# Altium schematic sheet Power.SchDoc(Power)
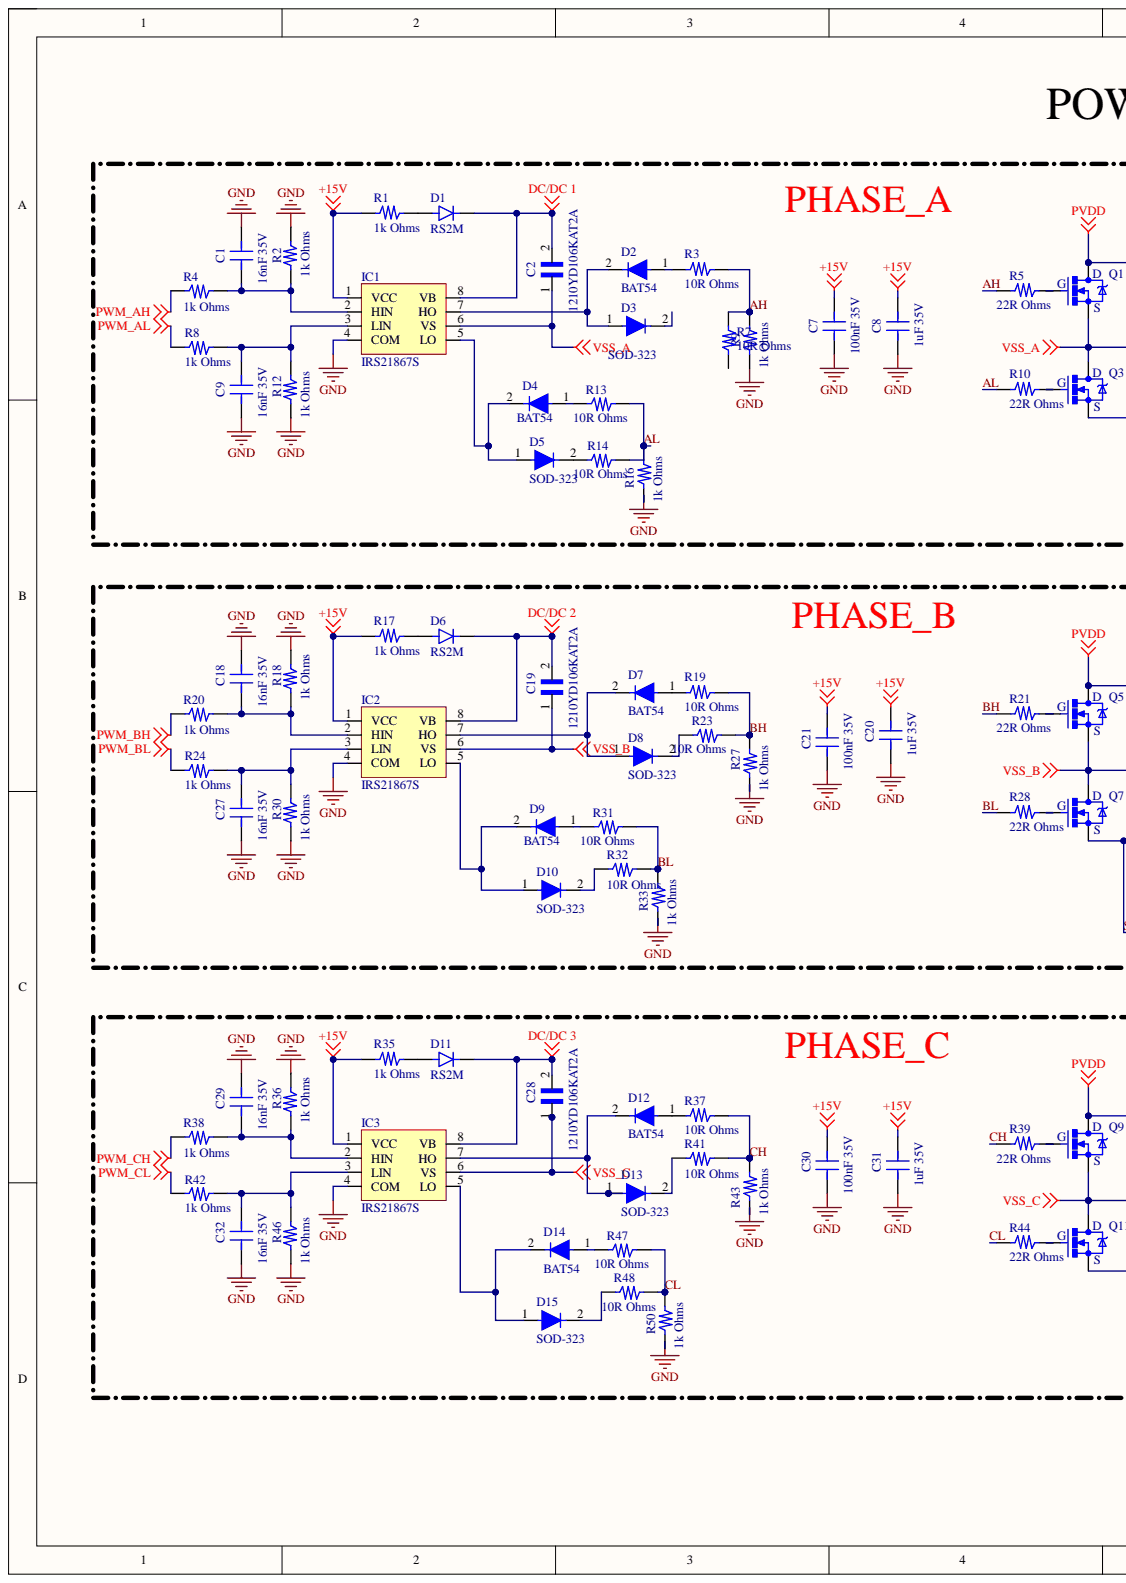
[diagram p0: Power.SchDoc, left]
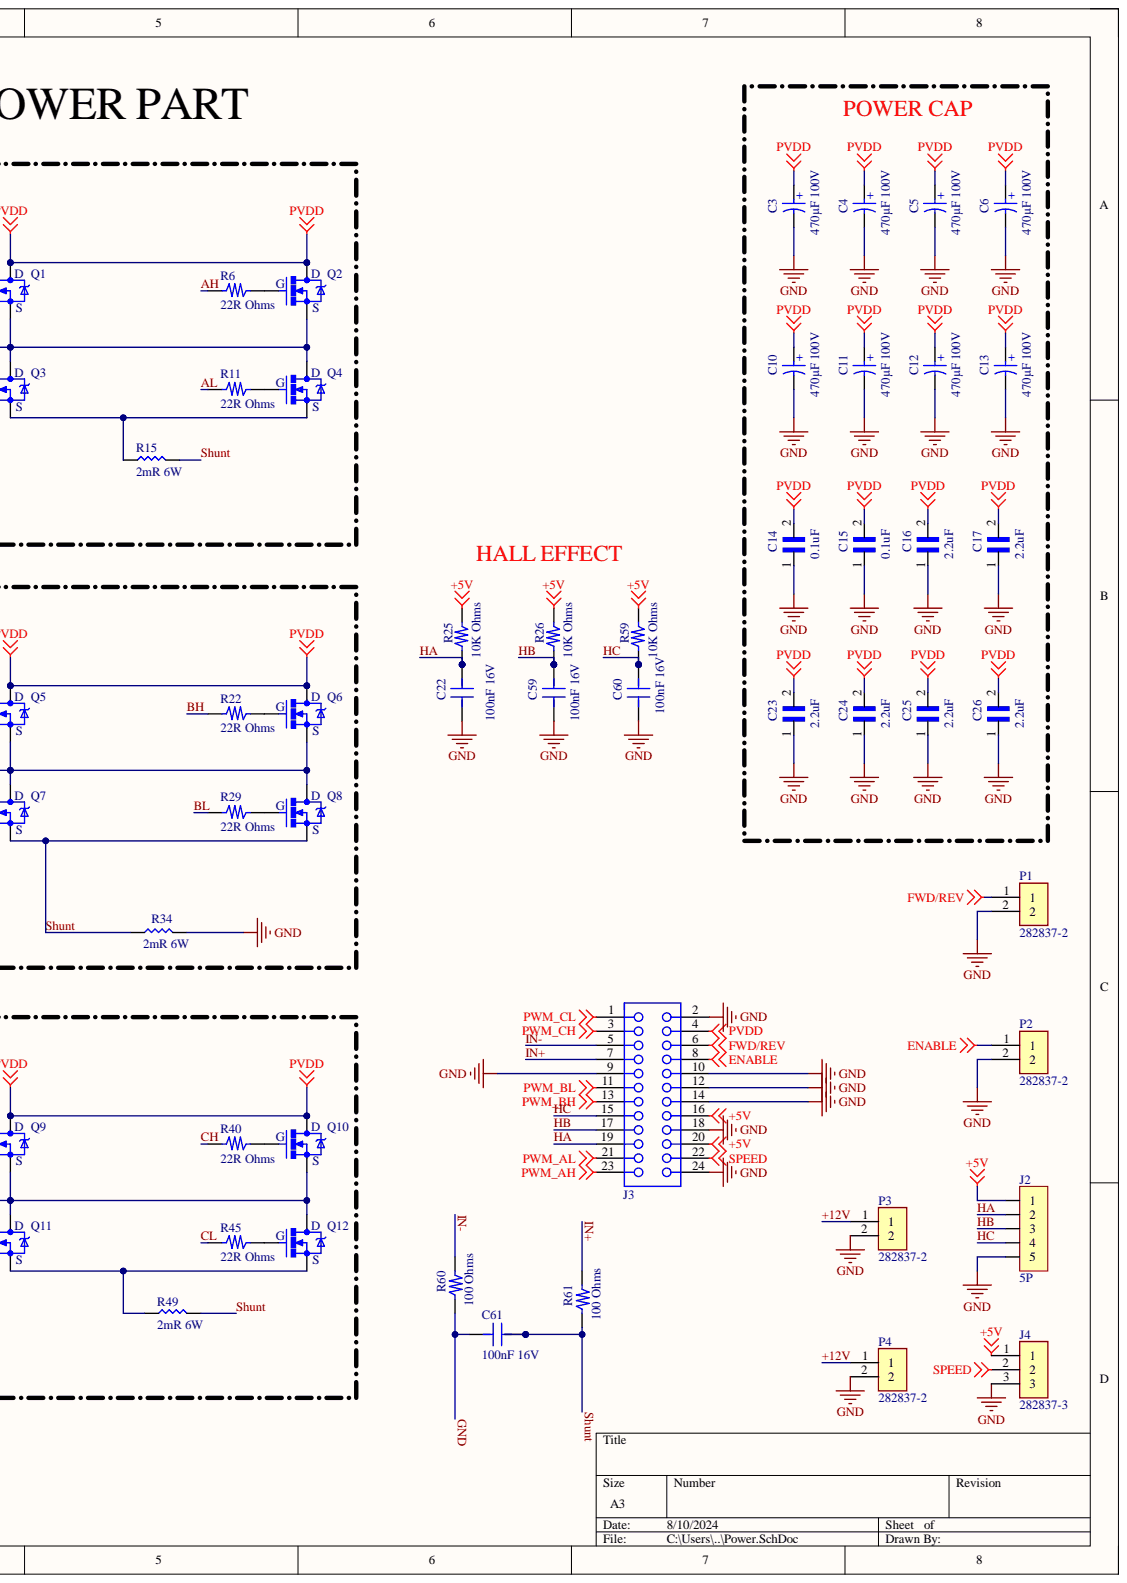
[diagram p1: Power.SchDoc, right]

## Parts
C1  16nF 35V  [0805_CAP]
C2  1210YD106KAT2A  [CAPC3225X279N]
C3  470µF 100V  Rated Voltage (DC): 100V  [WCAP-ATUL_D18H31.5]
C4  470µF 100V  Rated Voltage (DC): 100V  [WCAP-ATUL_D18H31.5]
C5  470µF 100V  Rated Voltage (DC): 100V  [WCAP-ATUL_D18H31.5]
C6  470µF 100V  Rated Voltage (DC): 100V  [WCAP-ATUL_D18H31.5]
C7  100nF 35V  [0805_CAP]
C8  value 1uF 35V  [0805_CAP]  (hidden comment: 10uF)
C9  16nF 35V  [0805_CAP]
C10  470µF 100V  Rated Voltage (DC): 100V  [WCAP-ATUL_D18H31.5]
C11  470µF 100V  Rated Voltage (DC): 100V  [WCAP-ATUL_D18H31.5]
C12  470µF 100V  Rated Voltage (DC): 100V  [WCAP-ATUL_D18H31.5]
C13  470µF 100V  Rated Voltage (DC): 100V  [WCAP-ATUL_D18H31.5]
C14  0.1uF  [CAPC3225X279N]
C15  0.1uF  [CAPC3225X279N]
C16  2.2uF  [CAPC3225X279N]
C17  2.2uF  [CAPC3225X279N]
C18  16nF 35V  [0805_CAP]
C19  1210YD106KAT2A  [CAPC3225X279N]
C20  value 1uF 35V  [0805_CAP]  (hidden comment: 10uF)
C21  100nF 35V  [0805_CAP]
C22  100nF 16V  [0805_CAP]
C23  2.2uF  [CAPC3225X279N]
C24  2.2uF  [CAPC3225X279N]
C25  2.2uF  [CAPC3225X279N]
C26  2.2uF  [CAPC3225X279N]
C27  16nF 35V  [0805_CAP]
C28  1210YD106KAT2A  [CAPC3225X279N]
C29  16nF 35V  [0805_CAP]
C30  100nF 35V  [0805_CAP]
C31  value 1uF 35V  [0805_CAP]  (hidden comment: 10uF)
C32  16nF 35V  [0805_CAP]
C59  100nF 16V  [0805_CAP]
C60  100nF 16V  [0805_CAP]
C61  100nF 16V  [0603_CAP]
D1  RS2M  [DIOM4336X265N]
D2  BAT54  [SOD323]
D3  SOD-323  [SOD323]
D4  BAT54  [SOD323]
D5  SOD-323  [SOD323]
D6  RS2M  [DIOM4336X265N]
D7  BAT54  [SOD323]
D8  SOD-323  [SOD323]
D9  BAT54  [SOD323]
D10  SOD-323  [SOD323]
D11  RS2M  [DIOM4336X265N]
D12  BAT54  [SOD323]
D13  SOD-323  [SOD323]
D14  BAT54  [SOD323]
D15  SOD-323  [SOD323]
IC1  IRS21867S  [IRS21867S]
IC2  IRS21867S  [IRS21867S]
IC3  IRS21867S  [IRS21867S]
J2  5P  [TBLOCK5P]
J3  61302421821  [61302421821]  (value not drawn)
J4  282837-3  [TE_282837-3]
P1  282837-2  [TE_282837-2]
P2  282837-2  [TE_282837-2]
P3  282837-2  [TE_282837-2]
P4  282837-2  [TE_282837-2]
Q1  IXTH200N10T  [TO-247]  (value not drawn)
Q2  IRFP4310ZPbF  [TO-247]  (value not drawn)
Q3  IRFP4310ZPbF  [TO-247]  (value not drawn)
Q4  IRFP4310ZPbF  [TO-247]  (value not drawn)
Q5  IXTH200N10T  [TO-247]  (value not drawn)
Q6  IRFP4310ZPbF  [TO-247]  (value not drawn)
Q7  IRFP4310ZPbF  [TO-247]  (value not drawn)
Q8  IRFP4310ZPbF  [TO-247]  (value not drawn)
Q9  IXTH200N10T  [TO-247]  (value not drawn)
Q10  IRFP4310ZPbF  [TO-247]  (value not drawn)
Q11  IRFP4310ZPbF  [TO-247]  (value not drawn)
Q12  IRFP4310ZPbF  [TO-247]  (value not drawn)
R1  1k Ohms  [0805_RES]
R2  1k Ohms  [0805_RES]
R3  10R Ohms  [0805_RES]
R4  1k Ohms  [0805_RES]
R5  22R Ohms  [0805_RES]
R6  22R Ohms  [0805_RES]
R7  10R Ohms  [0805_RES]
R8  1k Ohms  [0805_RES]
R9  1k Ohms  [0805_RES]
R10  22R Ohms  [0805_RES]
R11  22R Ohms  [0805_RES]
R12  1k Ohms  [0805_RES]
R13  10R Ohms  [0805_RES]
R14  10R Ohms  [0805_RES]
R15  2mR 6W  [3920]
R16  1k Ohms  [0805_RES]
R17  1k Ohms  [0805_RES]
R18  1k Ohms  [0805_RES]
R19  10R Ohms  [0805_RES]
R20  1k Ohms  [0805_RES]
R21  22R Ohms  [0805_RES]
R22  22R Ohms  [0805_RES]
R23  10R Ohms  [0805_RES]
R24  1k Ohms  [0805_RES]
R25  10K Ohms  [0805_RES]
R26  10K Ohms  [0805_RES]
R27  1k Ohms  [0805_RES]
R28  22R Ohms  [0805_RES]
R29  22R Ohms  [0805_RES]
R30  1k Ohms  [0805_RES]
R31  10R Ohms  [0805_RES]
R32  10R Ohms  [0805_RES]
R33  1k Ohms  [0805_RES]
R34  2mR 6W  [3920]
R35  1k Ohms  [0805_RES]
R36  1k Ohms  [0805_RES]
R37  10R Ohms  [0805_RES]
R38  1k Ohms  [0805_RES]
R39  22R Ohms  [0805_RES]
R40  22R Ohms  [0805_RES]
R41  10R Ohms  [0805_RES]
R42  1k Ohms  [0805_RES]
R43  1k Ohms  [0805_RES]
R44  22R Ohms  [0805_RES]
R45  22R Ohms  [0805_RES]
R46  1k Ohms  [0805_RES]
R47  10R Ohms  [0805_RES]
R48  10R Ohms  [0805_RES]
R49  2mR 6W  [3920]
R50  1k Ohms  [0805_RES]
R59  10K Ohms  [0805_RES]
R60  100 Ohms  [0603_RES]
R61  100 Ohms  [0603_RES]

## Nets
+5V: J2.1 J3.16 J3.20 J4.1 R25.2 R26.2 R59.2
+15V: C7.2 C8.2 C20.2 C21.2 C30.2 C31.2 IC1.1(VCC) IC2.1(VCC) IC3.1(VCC) R1.1 R17.1 R35.1
DC/DC 1: C2.2 D1.C IC1.8(VB)
DC/DC 2: C19.2 D6.C IC2.8(VB)
DC/DC 3: C28.2 D11.C IC3.8(VB)
ENABLE: J3.8 P2.1
FWD/REV: J3.6 P1.1
PVDD: C3.1 C4.1 C5.1 C6.1 C10.1 C11.1 C12.1 C13.1 C14.2 C15.2 C16.2 C17.2 C23.2 C24.2 C25.2 C26.2 J3.4 Q1.2 Q2.2 Q5.2 Q6.2 Q9.2 Q10.2
PWM_AH: J3.23 R4.2
PWM_AL: J3.21 R8.2
PWM_BH: J3.13 R20.2
PWM_BL: J3.11 R24.2
PWM_CH: J3.3 R38.2
PWM_CL: J3.1 R42.2
SPEED: J3.22 J4.2
VSS_A: C2.1 IC1.6(VS) Q1.3 Q2.3 Q3.2 Q4.2
VSS_B: C19.1 IC2.6(VS) Q5.3 Q6.3 Q7.2 Q8.2
VSS_C: C28.1 IC3.6(VS) Q9.3 Q10.3 Q11.2 Q12.2
+12V: P3.1 P4.1
AH: R3.2 R5.1 R6.1 R7.1 R9.1
AL: R10.1 R11.1 R13.1 R14.1 R16.1
BH: R19.2 R21.1 R22.1 R23.1 R27.1
BL: R28.1 R29.1 R31.1 R32.1 R33.1
CH: R37.2 R39.1 R40.1 R41.1 R43.1
CL: R44.1 R45.1 R47.1 R48.1 R50.1
GND: C1.2 C3.2 C4.2 C5.2 C6.2 C7.1 C8.1 C9.1 C10.2 C11.2 C12.2 C13.2 C14.1 C15.1 C16.1 C17.1 C18.2 C20.1 C21.1 C22.2 C23.1 C24.1 C25.1 C26.1 C27.1 C29.2 C30.1 C31.1 C32.1 C59.2 C60.1 C61.2 IC1.4(COM) IC2.4(COM) IC3.4(COM) J2.5 J3.2 J3.9 J3.10 J3.12 J3.14 J3.18 J3.24 J4.3 P1.2 P2.2 P3.2 P4.2 R2.2 R9.2 R12.1 R16.2 R18.2 R27.2 R30.1 R33.2 R34.2 R36.2 R43.2 R46.1 R50.2 R60.2
HA: C22.1 J2.2 J3.19 R25.1
HB: C59.1 J2.3 J3.17 R26.1
HC: C60.2 J2.4 J3.15 R59.1
IN+: J3.7 R61.1
IN-: J3.5 R60.1
Shunt: C61.1 Q7.3 Q8.3 R15.2 R34.1 R49.2 R61.2
NetC1_1: C1.1 IC1.2(HIN) R2.1 R4.1
NetC9_2: C9.2 IC1.3(LIN) R8.1 R12.2
NetC18_1: C18.1 IC2.2(HIN) R18.1 R20.1
NetC27_2: C27.2 IC2.3(LIN) R24.1 R30.2
NetC29_1: C29.1 IC3.2(HIN) R36.1 R38.1
NetC32_2: C32.2 IC3.3(LIN) R42.1 R46.2
NetD1_A: D1.A R1.2
NetD2_1: D2.1 R3.1
NetD2_2: D2.2 D3.1 IC1.7(HO)
NetD4_1: D4.1 R13.2
NetD4_2: D4.2 D5.1 IC1.5(LO)
NetD5_2: D5.2 R14.2
NetD6_A: D6.A R17.2
NetD7_1: D7.1 R19.1
NetD7_2: D7.2 D8.1 IC2.7(HO)
NetD8_2: D8.2 R23.2
NetD9_1: D9.1 R31.2
NetD9_2: D9.2 D10.1 IC2.5(LO)
NetD10_2: D10.2 R32.2
NetD11_A: D11.A R35.2
NetD12_1: D12.1 R37.1
NetD12_2: D12.2 D13.1 IC3.7(HO)
NetD13_2: D13.2 R41.2
NetD14_1: D14.1 R47.2
NetD14_2: D14.2 D15.1 IC3.5(LO)
NetD15_2: D15.2 R48.2
NetQ1_1: Q1.1 R5.2
NetQ2_1: Q2.1 R6.2
NetQ3_1: Q3.1 R10.2
NetQ3_3: Q3.3 Q4.3 R15.1
NetQ4_1: Q4.1 R11.2
NetQ5_1: Q5.1 R21.2
NetQ6_1: Q6.1 R22.2
NetQ7_1: Q7.1 R28.2
NetQ8_1: Q8.1 R29.2
NetQ9_1: Q9.1 R39.2
NetQ10_1: Q10.1 R40.2
NetQ11_1: Q11.1 R44.2
NetQ11_3: Q11.3 Q12.3 R49.1
NetQ12_1: Q12.1 R45.2

Not connected: D3 2; R7 2

## Library data of the parts
C1 C7-C9 C18 C20-C22 C27 C29-C32 C59 C60 = CAP_0805: Component Kind: Standard
  C1 C9 C18 C27 C29 C32: Value: 16nF 35V
  C7 C21 C30: Value: 100nF 35V
  C22 C59 C60: Value: 100nF 16V; Library Name: HidroanaSCHLib1.SchLib
  C1 C7-C9 C18 C20 C21 C27 C29-C32: Library Name: HidroanaSCHLib.SchLib
C2 C14-C17 C19 C23-C26 C28 = 1210YD106KAT2A: Cap Ceramic 10uF 16V X5R 10% SMD 1210 85Â°C Embossed T/R; Availability: Unavailable; Component Kind: Standard; Library Name: 1210YD106KAT2A.IntLib; MP: 1210YD106KAT2A; Package: None; Price: None
C3-C6 C10-C13 = 860040881012: WCAP-ATUL Aluminum Electrolytic Capacitor, Radial, THT, D18 x H31.5mm, 470µF, 100V; Manufacturer: Wurth Elektronik; Capacitance Tolerance: 20%; Dissipation Factor: 9%; Endurance: 10000h; Leakage Current: 470µA; Ripple Current: 1600mA; Technical Reference: ATDL315471M100DSPAEK000; Capacitance: 470µF 100V; Category: Aluminum Electrolytic Capacitors; Component Kind: Standard; ComponentLink1Description: Website Link; ComponentLink1URL: https://www.we-online.com/redexpert/article/860040881012?ad; ComponentLink2Description: Datasheet Link; ComponentLink2URL: https://www.we-online.com/redexpert/spec/860040881012?ad; Diameter: 18mm; Height: 31.5mm; Manufacturer Part Number: 860040881012; Match Code: WCAP-ATUL; Mount: Through Hole; Operating Temperature Max: 105°C; Operating Temperature Min: -40°C; Packaging: Ammo Pack; Simulation: 860040881012_470uF; Library Name: Hidroana Lib.IntLib
C61 = CAP_0603: CL10B105KO8NNNC - MLCC (1608) 0603 1uF 16VDC ±10% X7R; Component Kind: Standard; Value: 100nF 16V; Library Name: HidroanaSCHLib.SchLib
D1 D6 D11 = RS2M: 2A, 50V - 1000V Fast Recovery Surface Mount Rectifier; MF: Taiwan Semiconductor; Availability: In Stock; Check_prices: https://www.snapeda.com/parts/RS2M/Taiwan+Semiconductor/view-part/?ref=eda; Component Kind: Standard; Library Name: RS2M.IntLib; MP: RS2M; Package: DO-214AA-2 Taiwan Semiconductor; Price: None; SnapEDA_Link: https://www.snapeda.com/parts/RS2M/Taiwan+Semiconductor/view-part/?ref=snap
D2-D5 D7-D10 D12-D15 = diode/sod323: Component Kind: Standard
  D2 D4 D7 D9 D12 D14: Library Name: HidroanaSCHLib1.SchLib
  D3 D5 D8 D10 D13 D15: Library Name: Hidroana Lib.IntLib
J2 = TBLOCK5P: Component Kind: Standard; Library Name: HidroanaSCHLib1.SchLib
J3 = 61302421821: WR-PHD Socket Header, THT, Vertical, pitch 2.54mm, 2 Row, 24P; Manufacturer: Wurth Elektronik; Contact Resistance: 20mOhm; Rated Current: 3A; Withstanding Voltage: 500V; Working Voltage: 250V; Category: Board-to-Board Connectors; Component Kind: Standard; ComponentLink1Description: Website Link; ComponentLink1URL: https://www.we-online.com/redexpert/article/61302421821?ad; ComponentLink2Description: Datasheet Link; ComponentLink2URL: https://www.we-online.com/redexpert/spec/61302421821?ad; Gender: Socket Header; Library Name: Wurth.IntLib; Manufacturer Part Number: 61302421821; Match Code: WR-PHD; Mount: Through Hole; Number of Pins: 24; Number of Rows: 2; Operating Temperature Max: 125°C; Operating Temperature Min: -40°C; Orientation: Vertical; Packaging: Tray; Pitch: 2.54mm
J4 = 282837-3: TERMI-BLOK PCB MOUNT 3P; Availability: Unavailable; Component Kind: Standard; MP: 282837-3; Package: None; Price: None; Library Name: TBLOCK-I3.IntLib
P1-P4 = 282837-2: Ð Ð°Ð·ÑŠÐµÐ¼Ð½Ñ‹Ðµ ÐºÐ»ÐµÐ¼Ð¼Ð½Ñ‹Ðµ ÐºÐ¾Ð»Ð¾Ð´ÐºÐ¸ Ð Ð¾Ð·ÐµÑ‚ÐºÐ° 2 Ð¿Ð¾Ð»Ð¾Ð¶ÐµÐ½Ð¸Ñ 5,08 Ð¼Ð¼ ÐŸÑ€Ð¸Ð¿Ð¾Ð¹ Ð¿Ñ€ÑÐ¼Ð¾Ð¹ Ñ‡ÐµÑ€ÐµÐ· Ð¾Ñ‚Ð²ÐµÑ€ÑÑ‚Ð¸Ðµ 13,5 Ð / ÐºÐ¾Ð½Ñ‚Ð°ÐºÑ‚; MF: TE Connectivity; Availability: In Stock; Check_prices: https://www.snapeda.com/parts/282837-2/TE+Connectivity+AMP+Connectors/view-part/?ref=eda; Component Kind: Standard; MP: 282837-2; Package: None; Price: None; Purchase-URL: https://pricing.snapeda.com/search/part/282837-2/?ref=eda; SnapEDA_Link: https://www.snapeda.com/parts/282837-2/TE+Connectivity+AMP+Connectors/view-part/?ref=snap; Library Name: TBLOCK-I2.IntLib
Q1-Q12 = IRFP4310ZPbF: IRFP4310ZPBF - MOSFET DIS.120A 100V N-CH TO247AC HEXFET THT; Current Rate: 120A; Component Kind: Standard; ComponentLink1Description: Özdisan; ComponentLink1URL: https://ozdisan.com/Product/Detail/480417/IRFP4310ZPBF; ComponentLink2Description: Datasheet; ComponentLink2URL: https://cdn.ozdisan.com/ETicaret_Dosya/480417_1248700.pdf; Voltage Rate: 100V; Library Name: HidroanaSCHLib.SchLib
  Q1 Q5 Q9: PartValue: IXTH200N10T
  Q2-Q4 Q6-Q8 Q10-Q12: PartValue: IRFP4310ZPbF
R1-R6 R8-R13 R16-R22 R24 R27-R31 R33 R35-R40 R42-R47 R50 = RES_0805: 0805; Power: 1/8 W; Component Kind: Standard
  R1 R2 R4 R8 R9 R12 R16-R18 R20 R24 R27 R30 R33 R35 R36 R38 R42 R43 R46 R50: Value: 1k Ohms
  R3 R13 R19 R31 R37 R47: Value: 10R Ohms
  R5 R6 R10 R11 R21 R22 R28 R29 R39 R40 R44 R45: Value: 22R Ohms; Library Name: HidroanaSCHLib.SchLib
  R1 R2 R4 R8 R12 R17 R18 R20 R24 R30 R35 R36 R38 R42 R46: Library Name: Hidroana Lib.IntLib
  R3 R9 R13 R16 R19 R27 R31 R33 R37 R43 R47 R50: Library Name: HidroanaSCHLib1.SchLib
R7 R14 R23 R25 R26 R32 R41 R48 R59 = RES_0805: 0805; Power: 1/8 W; Value: 1k Ohms; Component Kind: Standard; Library Name: HidroanaSCHLib1.SchLib
R15 R34 R49 = Shunt: 3920; Component Kind: Standard; Library Name: HidroanaSCHLib1.SchLib
R60 R61 = RES_0603: 0603; Power: 1/8W; Value: 82 Ohms; Component Kind: Standard; Library Name: HidroanaSCHLib.SchLib
IC1-IC3 = IRS21867S: Component Kind: Standard; Description: IRS21867S; Library Name: IRS21867S.IntLib
